# Altium schematic sheet Driver Fet.SchDoc("Driver Fet")
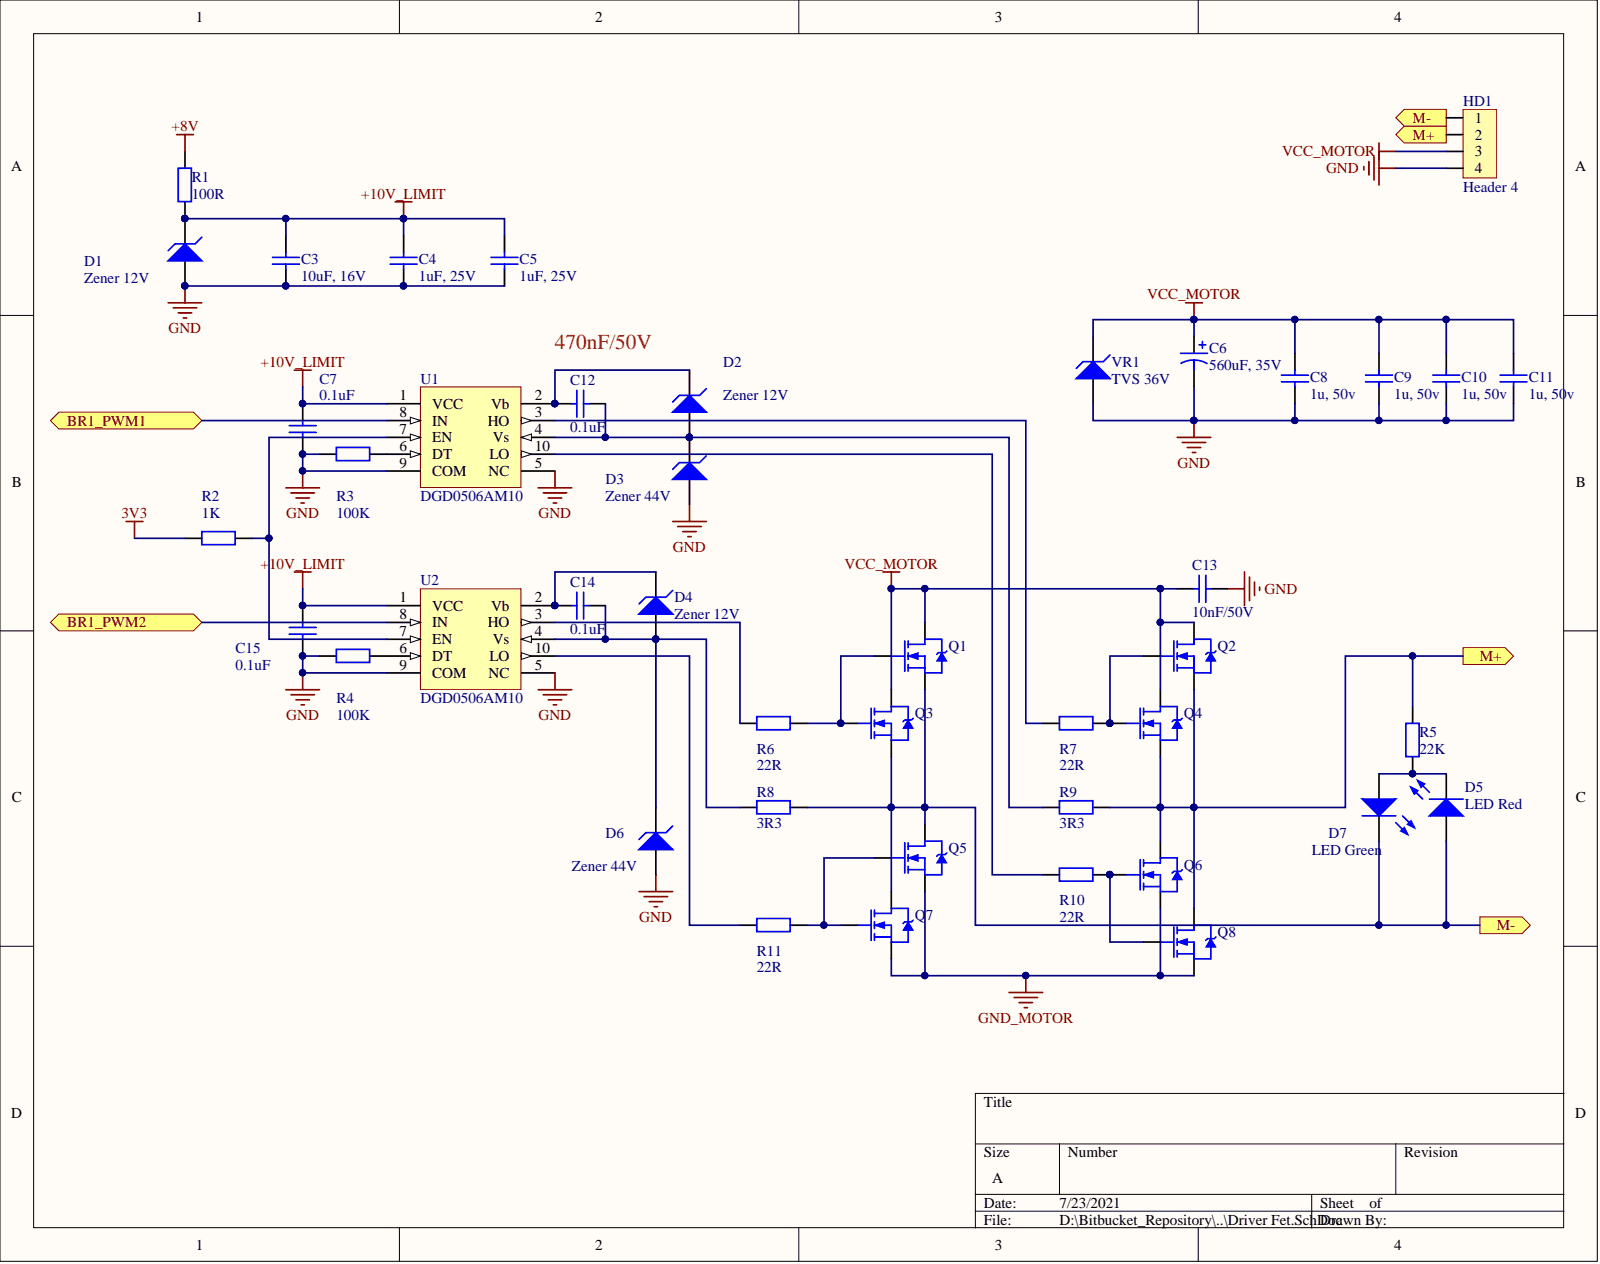
[diagram p0: Driver Fet.SchDoc]

## Parts
C3  value 10uF, 16V  [C0805]  (hidden comment: 10uF ±10% 25V X5R 0805 Multilayer Ceramic Capacitors MLCC - SMD/SMT RoHS)
C4  value 1uF, 25V  [C0603]  (hidden comment: Ceramic Cap 0603 1uF 25V 10% X5R)
C5  value 1uF, 25V  [C0603]  (hidden comment: Ceramic Cap 0603 1uF 25V 10% X5R)
C6  value 560uF, 35V  [C10x25 P5]  (hidden comment: Aluminum Cap 560uF 35V 20%)
C7  value 0.1uF  [C0603]  (hidden comment: Ceramic Cap 0603 0.1uF 50V 10% X7R)
C8  value 1u, 50v  [C1206NP]  (hidden comment: Ceramic Cap 1206 1uF 50V 10% X5R)
C9  value 1u, 50v  [C1206NP]  (hidden comment: Ceramic Cap 1206 1uF 50V 10% X5R)
C10  value 1u, 50v  [C1206NP]  (hidden comment: Ceramic Cap 1206 1uF 50V 10% X5R)
C11  value 1u, 50v  [C1206NP]  (hidden comment: Ceramic Cap 1206 1uF 50V 10% X5R)
C12  value 0.1uF  [C0603]  (hidden comment: Ceramic Cap 0603 0.1uF 50V 10% X7R)
C13  value 10nF/50V  [C0603]  (hidden comment: Ceramic Cap 0603 0.01uF 50V 10% X7R)
C14  value 0.1uF  [C0603]  (hidden comment: Ceramic Cap 0603 0.1uF 50V 10% X7R)
C15  value 0.1uF  [C0603]  (hidden comment: Ceramic Cap 0603 0.1uF 50V 10% X7R)
D1  value Zener 12V  [SOD-323]  (hidden comment: DIODE ZENER 12V 300MW SOD323)
D2  value Zener 12V  [SOD-323]  (hidden comment: DIODE ZENER 12V 300MW SOD323)
D3  value Zener 44V  [SOD-323]  (hidden comment: BAS316)
D4  value Zener 12V  [SOD-323]  (hidden comment: DIODE ZENER 12V 300MW SOD323)
D5  LED Red  [LED0603-R]
D6  value Zener 44V  [SOD-323]  (hidden comment: BAS316)
D7  LED Green  [LED0603-G]
HD1  Header 4  [TERMINAL_BLOCK_4_KF7.62]
Q1  IRFR3806TRPBF  [TO-252]  (value not drawn)
Q2  IRFR3806TRPBF  [TO-252]  (value not drawn)
Q3  IRFR3806TRPBF  [TO-252]  (value not drawn)
Q4  IRFR3806TRPBF  [TO-252]  (value not drawn)
Q5  IRFR3806TRPBF  [TO-252]  (value not drawn)
Q6  IRFR3806TRPBF  [TO-252]  (value not drawn)
Q7  IRFR3806TRPBF  [TO-252]  (value not drawn)
Q8  IRFR3806TRPBF  [TO-252]  (value not drawn)
R1  value 100R  [R0603]  (hidden comment: RES 100R 5% 1/10W 0603)
R2  value 1K  [R0603]  (hidden comment: RES 1K 1% 1/10W 0603)
R3  value 100K  [R0603]  (hidden comment: RES 100K 1% 1/10W 0603)
R4  value 100K  [R0603]  (hidden comment: RES 100K 1% 1/10W 0603)
R5  value 22K  [R0603]  (hidden comment: RES 22K 5% 1/10W 0603)
R6  value 22R  [R0603]  (hidden comment: RES 22R 5% 1/10W 0603)
R7  value 22R  [R0603]  (hidden comment: RES 22R 5% 1/10W 0603)
R8  value 3R3  [R0603]  (hidden comment: RES 3R3 1/10W 0603)
R9  value 3R3  [R0603]  (hidden comment: RES 3R3 1/10W 0603)
R10  value 22R  [R0603]  (hidden comment: RES 22R 5% 1/10W 0603)
R11  value 22R  [R0603]  (hidden comment: RES 22R 5% 1/10W 0603)
U1  DGD0506AM10  [MSOP-10]
U2  DGD0506AM10  [MSOP-10]
VR1  TVS 36V  [DO-214AC]

## Ports
BR1_PWM1, BR1_PWM2, M+, M-

## Nets
3V3: R2.2
+8V: R1.2
+10V_LIMIT: C3.2 C4.2 C5.2 C7.2 C15.2 D1.2 R1.1 U1.1(VCC) U2.1(VCC)
GND: C3.1 C4.1 C5.1 C6.2 C7.1 C8.1 C9.1 C10.1 C11.1 C13.2 C15.1 D1.1 D3.1 D6.1 HD1.4 R3.1 R4.1 U1.5(NC) U1.9(COM) U2.5(NC) U2.9(COM) VR1.1
GND_MOTOR: Q5.3 Q6.3 Q7.3 Q8.3
VCC_MOTOR: C6.1 C8.2 C9.2 C10.2 C11.2 C13.1 HD1.3 Q1.2 Q2.2 Q3.2 Q4.2 VR1.2
BR1_PWM1: U1.8(IN) ; port BR1_PWM1
BR1_PWM2: U2.8(IN) ; port BR1_PWM2
NetC12_1: C12.1 D2.1 D3.2 R9.1 U1.4(Vs)
NetC12_2: C12.2 D2.2 U1.2(Vb)
NetC14_1: C14.1 D4.1 D6.2 R8.1 U2.4(Vs)
NetC14_2: C14.2 D4.2 U2.2(Vb)
NetD5_1: D5.1 D7.2 HD1.1 Q1.3 Q3.3 Q5.2 Q7.2 R8.2
NetD5_2: D5.2 D7.1 R5.1
NetHD1_2: HD1.2 Q2.3 Q4.3 Q6.2 Q8.2 R5.2 R9.2
NetQ1_1: Q1.1 Q3.1 R6.2
NetQ2_1: Q2.1 Q4.1 R7.2
NetQ5_1: Q5.1 Q7.1 R11.2
NetQ6_1: Q6.1 Q8.1 R10.2
NetR2_1: R2.1 U1.7(EN) U2.7(EN)
NetR3_2: R3.2 U1.6(DT)
NetR4_2: R4.2 U2.6(DT)
NetR6_1: R6.1 U2.3(HO)
NetR7_1: R7.1 U1.3(HO)
NetR10_1: R10.1 U1.10(LO)
NetR11_1: R11.1 U2.10(LO)

## Library data of the parts
C3 = CL21A106KAYNNNE: Component Kind: Standard; Description: 10uF ±10% 25V X5R 0805 Multilayer Ceramic Capacitors MLCC - SMD/SMT RoHS; Library Name: capacitor.SchLib
C4 = GRM188R61E105KA12: Murata General Purpose Ceramic Cap 0603 1uF 25V 10% X5R; Value: 1uF, 25V; Component Kind: Standard; Library Name: capacitor.SchLib; Signal Integrity: Cap; Simulation: CAP; Supplier 1: Digi-Key; Supplier Part Number 1: 490-6414-1-ND; Supplier Part Number 2: FL1114
C5 = GRM188R61E105KA12: Murata General Purpose Ceramic Cap 0603 1uF 25V 10% X5R; Component Kind: Standard; Library Name: capacitor.SchLib; Signal Integrity: Cap; Simulation: CAP; Supplier 1: Digi-Key; Supplier Part Number 1: 490-6414-1-ND; Supplier Part Number 2: FL1114
C6 = 35ZLS560MEFC10X20: Rubicon Aluminum Cap 560uF 35V 20%; Value: 560uF, 35V; Component Kind: Standard; Library Name: capacitor.SchLib; Signal Integrity: Cap Pol; Simulation: CAP; Supplier 1: Digi-Key; Supplier Part Number 1: 1189-2599-ND
C7 C12 C14 C15 = GRM188R71H104KA93: Murata General Purpose Ceramic Cap 0603 0.1uF 50V 10% X7R; Component Kind: Standard; Library Name: capacitor.SchLib; Signal Integrity: Cap; Simulation: CAP; Supplier 1: Digi-Key; Supplier Part Number 1: 490-1519-1-ND; Supplier Part Number 2: FL1028
C8-C11 = C3216X5R1H105K: TDK General Purpose Ceramic Cap 1206 1uF 50V 10% X5R; Component Kind: Standard; Library Name: capacitor.SchLib; Signal Integrity: Cap; Simulation: CAP; Supplier 1: Digi-Key; Supplier Part Number 1: 445-4044-1-ND
C13 = GRM188R71H103KA01: Murata General Purpose Ceramic Cap 0603 0.01uF 50V 10% X7R; Component Kind: Standard; Library Name: capacitor.SchLib; Signal Integrity: Cap; Simulation: CAP; Supplier 1: Digi-Key; Supplier Part Number 1: 490-1512-1-ND; Supplier Part Number 2: FL1129
D1 D2 D4 = MM3Z12VT1G: Component Kind: Standard; Description: DIODE ZENER 12V 300MW SOD323; Library Name: diode.SchLib; Supplier 1: Digi-Key; Supplier Part Number 1: MM3Z12VT1GOSTR-ND; Supplier Part Number 2: FL1515
D3 D6 = BZT52C47: Micro Commercial Zener 44V  SOD-123; Component Kind: Standard; Library Name: diode.SchLib
D5 = LTST-C190KRKT: Lite-On LED Red 0603; Component Kind: Standard; Library Name: diode.SchLib; Supplier 1: Digi-Key; Supplier Part Number 1: 160-1436-1-ND; Supplier Part Number 2: FL1413; Value: LED Red
D7 = LTST-C190KGKT: Lite-On LED Green 0603; Component Kind: Standard; Library Name: diode.SchLib; Supplier 1: Digi-Key; Supplier Part Number 1: 160-1435-1-ND; Supplier Part Number 2: FL1526; Value: LED Green
HD1 = Hed4: Header 4 (Green); Component Kind: Standard; Value: Header 4; Library Name: MrLinh_Sch.SchLib
Q1-Q8 = IRFR3806TRPBF: MOSFET N-CH D-S 60V 43A DPAK; Component Kind: Standard; Library Name: fet.SchLib; Supplier 1: Digi-Key; Supplier Part Number 1: IRFR3806TRPBFCT-ND; Value: IRFR3806TRPBF
R1 = RC0603JR-07100RL: Yageo RES 100R 5% 1/10W 0603; Component Kind: Standard; Library Name: resistor.SchLib; Signal Integrity: Res; Simulation: RESISTOR; Supplier 1: Digi-Key; Supplier Part Number 1: 311-100GRCT-ND; Supplier Part Number 2: FL2438
R2 = RC0603FR-071KL: Yageo RES 1K 1% 1/10W 0603; Component Kind: Standard; Library Name: resistor.SchLib; Signal Integrity: Res; Simulation: RESISTOR; Supplier 1: Digi-Key; Supplier Part Number 1: 311-1.00KHRCT-ND
R3 R4 = RC0603FR-07100KL: Yageo RES 100K 1% 1/10W 0603; Component Kind: Standard; Library Name: resistor.SchLib; Signal Integrity: Res; Simulation: RESISTOR; Supplier 1: Digi-Key; Supplier Part Number 1: 311-100KHRCT-ND
R5 = RC0603JR-0722KL: Yageo RES 22K 5% 1/10W 0603; Component Kind: Standard; Library Name: resistor.SchLib; Signal Integrity: Res; Simulation: RESISTOR; Supplier 1: Digi-Key; Supplier Part Number 1: 311-22KGRCT-ND
R6 R7 R10 R11 = RC0603JR-0722RL: Yageo RES 22R 5% 1/10W 0603; Component Kind: Standard; Library Name: resistor.SchLib; Signal Integrity: Res; Simulation: RESISTOR; Supplier 1: Digi-Key; Supplier Part Number 1: 311-22GRCT-ND
R8 R9 = RC0603FR-073R3L: Yageo RES 3R3 1%  1/10W 0603; Component Kind: Standard; Library Name: resistor.SchLib; Signal Integrity: Res; Simulation: RESISTOR; Supplier 1: Digi-Key
U1 U2 = DGD0506AM10-13: Half-Bridge Drivers; Component Kind: Standard; Library Name: ic.SchLib; Supplier 1: Digi-Key; Supplier Part Number 1: DGD0506AM10-13
VR1 = SMAJ36AHE3: TVS DIODE 36VWM 58.1VC SMA; Component Kind: Standard; Library Name: diode.SchLib; Supplier 1: Digi-Key; Supplier Part Number 1: SMAJ36AHE3/61CT-ND; Value: TVS 36V
